# Altium schematic sheet ESP32_T&H_Interface.SchDoc(ESP32_T&H_Interface)
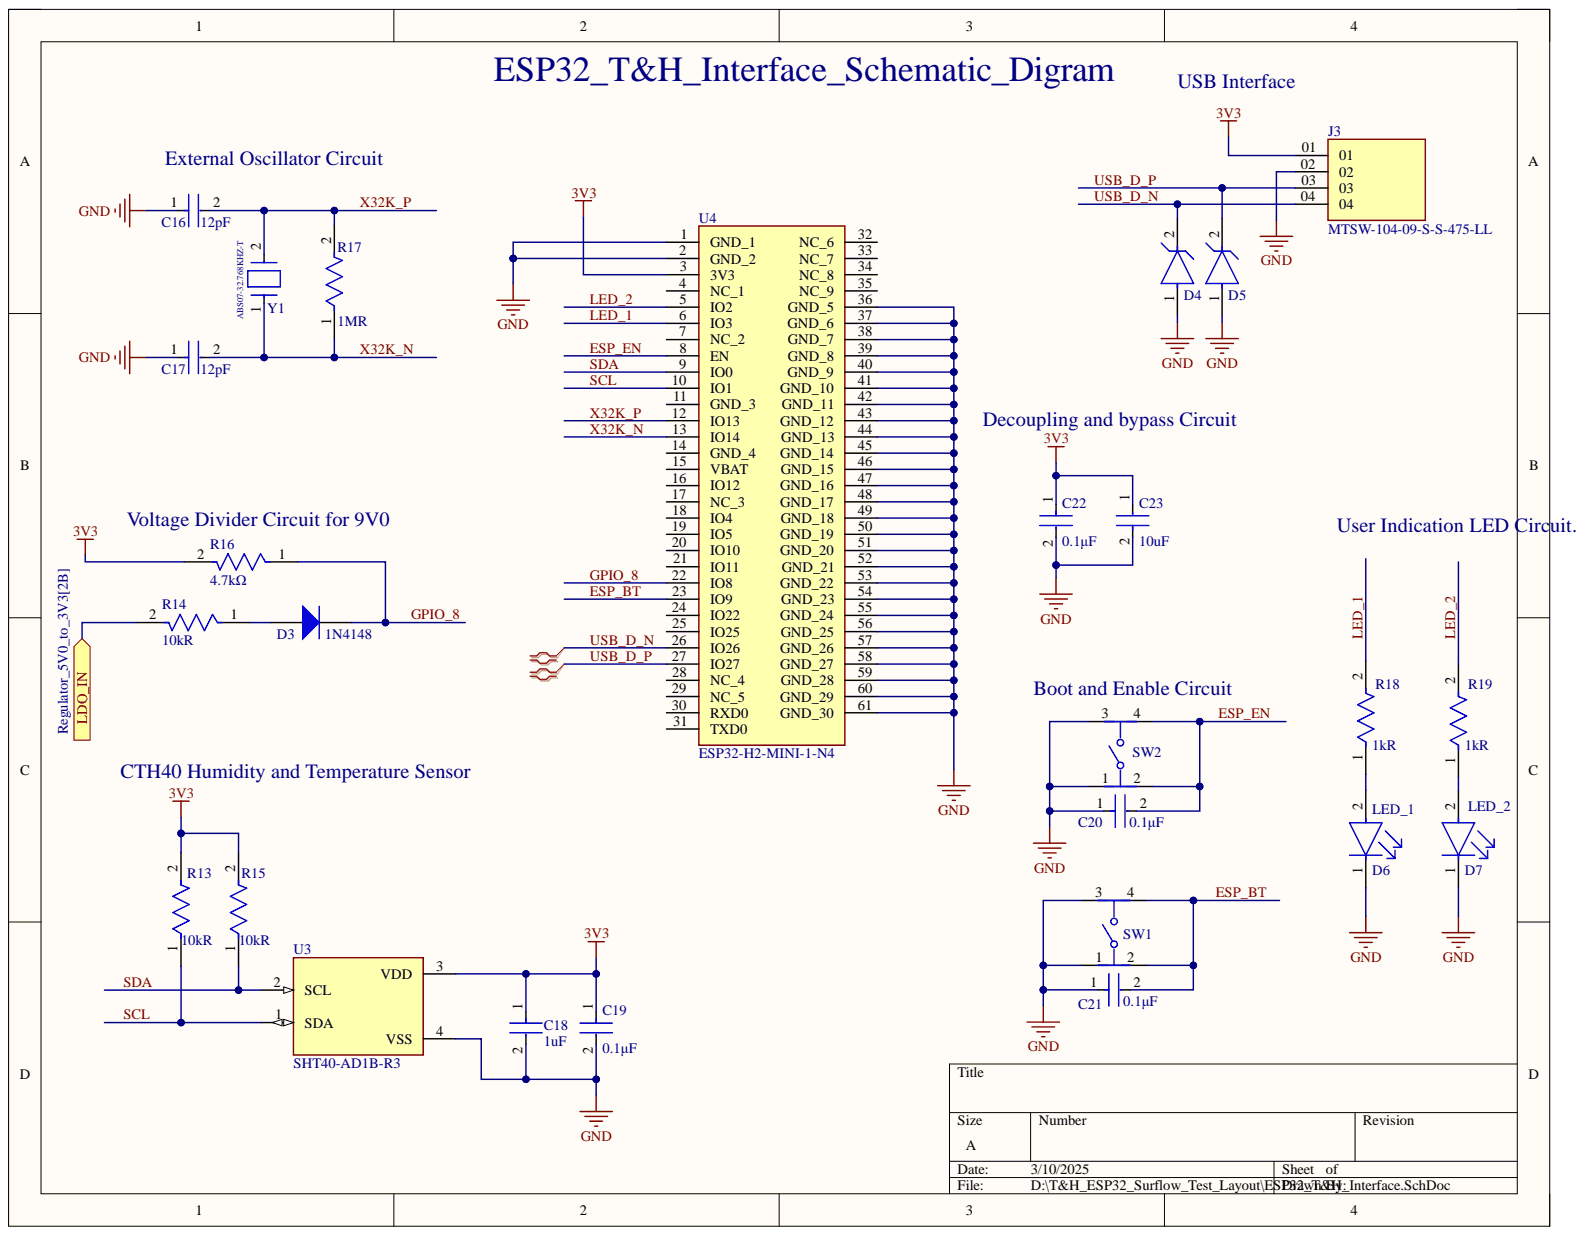
[diagram p0: ESP32_T&H_Interface.SchDoc]

## Parts
C16  Capacitance: 12pF  [FP-0402-L_1_0_1-W_0_5_0_1-IPC_B]  (hidden comment: 04025A120JAT2A)
C17  Capacitance: 12pF  [FP-0402-L_1_0_1-W_0_5_0_1-IPC_B]  (hidden comment: 04025A120JAT2A)
C18  value 1uF  Capacitance: 1uF  [FP-GRM15-0_1-MFG]  (hidden comment: GRM155R61A105KE15D)
C19  Capacitance: 0.1μF  [FP-C0603C-CF-MFG]  (hidden comment: C0603C104K8RACTU)
C20  Capacitance: 0.1μF  [FP-C0603C-CF-MFG]  (hidden comment: C0603C104K8RACTU)
C21  Capacitance: 0.1μF  [FP-C0603C-CF-MFG]  (hidden comment: C0603C104K8RACTU)
C22  Capacitance: 0.1μF  [FP-C0603C-CF-MFG]  (hidden comment: C0603C104K8RACTU)
C23  Capacitance: 10uF  [FP-C1608-080-0_1-IPC_A]  (hidden comment: C1608X5R1A106M080AC)
D3  1N4148  [DIOD-SOD323-2-A_V]
D4  UCLAMP3601P.TNT  [FP-SLP1006P2-MFG]  (value not drawn)
D5  UCLAMP3601P.TNT  [FP-SLP1006P2-MFG]  (value not drawn)
D6  APHHS1005CGCK  [FP-APHHS1005CGCK-MFG]  (value not drawn)
D7  APHHS1005CGCK  [FP-APHHS1005CGCK-MFG]  (value not drawn)
J3  MTSW-104-09-S-S-475-LL  [SAMTEC_MTSW-104-09-S-S-475-LL]
R13  Resistance: 10kR  [FP-AC0402-MFG]  (hidden comment: AC0402FR-0710KL)
R14  Resistance: 10kR  [FP-AC0402-MFG]  (hidden comment: AC0402FR-0710KL)
R15  Resistance: 10kR  [FP-AC0402-MFG]  (hidden comment: AC0402FR-0710KL)
R16  Resistance: 4.7kΩ  [FP-RC0402-0_4-MFG]  (hidden comment: RC0402JR-074K7L)
R17  Resistance: 1MR  [FP-MCR01-IPC_B]  (hidden comment: MCR01MRTF1004)
R18  Resistance: 1kR  [FP-RMCF0402-MFG]  (hidden comment: RMCF0402FT1K00)
R19  Resistance: 1kR  [FP-RMCF0402-MFG]  (hidden comment: RMCF0402FT1K00)
SW1  KMR221NGLFS  [FP-KMR221NGLFS-0]  (value not drawn)
SW2  KMR221NGLFS  [FP-KMR221NGLFS-0]  (value not drawn)
U3  SHT40-AD1B-R3  [SHT4X]
U4  ESP32-H2-MINI-1-N4  [ESP32H2MINI1N4]
Y1  ABS07-32.768KHZ-T  [FP-ABS07-MFG]

## Ports
LDO_IN

## Nets
3V3: C18.1 C19.1 C22.1 C23.1 J3.01 R13.2 R15.2 R16.2 U3.3(VDD) U4.3(3V3)
GND: C16.1 C17.1 C18.2 C19.2 C20.1 C21.1 C22.2 C23.2 D4.1 D5.1 D6.1 D7.1 J3.02 SW1.1 SW1.3 SW2.1 SW2.3 U3.4(VSS) U4.1(GND_1) U4.2(GND_2) U4.36(GND_5) U4.37(GND_6) U4.38(GND_7) U4.39(GND_8) U4.40(GND_9) U4.41(GND_10) U4.42(GND_11) U4.43(GND_12) U4.44(GND_13) U4.45(GND_14) U4.46(GND_15) U4.47(GND_16) U4.48(GND_17) U4.49(GND_18) U4.50(GND_19) U4.51(GND_20) U4.52(GND_21) U4.53(GND_22) U4.54(GND_23) U4.55(GND_24) U4.56(GND_25) U4.57(GND_26) U4.58(GND_27) U4.59(GND_28) U4.60(GND_29) U4.61(GND_30)
ESP_BT: C21.2 SW1.2 SW1.4 U4.23(IO9)
ESP_EN: C20.2 SW2.2 SW2.4 U4.8(EN)
GPIO_8: D3.2 R16.1 U4.22(IO8)
LDO_IN: R14.2 ; port LDO_IN
LED_1: R18.2 U4.6(IO3)
LED_2: R19.2 U4.5(IO2)
SCL: R13.1 U3.1(SDA) U4.10(IO1)
SDA: R15.1 U3.2(SCL) U4.9(IO0)
USB_D_N: D4.2 J3.04 U4.26(IO26)
USB_D_P: D5.2 J3.03 U4.27(IO27)
X32K_N: C17.2 R17.1 U4.13(IO14) Y1.1
X32K_P: C16.2 R17.2 U4.12(IO13) Y1.2
NetD3_1: D3.1 R14.1
NetD6_2: D6.2 R18.1
NetD7_2: D7.2 R19.1

Not connected: U4 4(NC_1) 7(NC_2) 11(GND_3) 14(GND_4) 15(VBAT) 16(IO12) 17(NC_3) 18(IO4) 19(IO5) 20(IO10) 21(IO11) 24(IO22) 25(IO25) 28(NC_4) 29(NC_5) 30(RXD0) 31(TXD0) 32(NC_6) 33(NC_7) 34(NC_8) 35(NC_9)

## Library data of the parts
C16 C17 = CMP-2008-02574-2: General Purpose Ceramic Capacitor, 0402, 12pF, 5%, C0G, 30ppm/°C, 50V; Insulation Resistance: 100GR; Case Code (Imperial): 0402; Case Code (Metric): 1005; Case/Package: 0402; Component Kind: Standard; Construction: Flat; Depth: 0.5mm; Dielectric: C0G; Height: 0.5mm; Lead Free: Lead Free; Length: 1mm; Library Name: Altium Content Vault; Max Operating Temperature: 125°C; Min Operating Temperature: -55°C; Mount: Surface Mount; Number of Pins: 2; Package Quantity: 1; Packaging: Tape and Reel; Radiation Hardening: No; REACH SVHC: No SVHC; RoHS Compliant: Yes; Series: -; Temperature Coefficient: 30ppm/°C; Termination: SMD/SMT; Thickness: 0.022inch; Tolerance: 5%; Voltage: 50V; Voltage Rating: 50V; Voltage Rating (DC): 50V; Width: 0.02inch
C18 = CMP-2008-04556-2: CAP CER 1UF 10V X5R 0402; Manufacturer: Murata; Material: Ceramic; Temperature Coefficient: 15%; Tolerance: 10%; Automotive: No; Category: Cap; Component Kind: Standard; ComponentLink2Description: Datasheet; ComponentLink2URL: https://search.murata.co.jp/Ceramy/image/img/A01X/G101/ENG/GRM155R61A105KE15-01A.pdf; Device Class L1: Passive Components; Device Class L2: Capacitors; Device Class L3: Ceramic Capacitors; Digikey Description: CAP CER 1UF 10V X5R 0402; Height: 0.55mm; Ipc Land Pattern Name: CAPC10050X50; Lead Free: Yes; Library Name: Altium Content Vault; Manufacturer Part Number: GRM155R61A105KE15D; Mouser Description: 0402 1 uF 10 V ±10% Tolerance X5R Surface Mount Multilayer Ceramic Capacitor; Octopart: https://octopart.com/grm155r61a105ke15d-murata-197442; Package: GRM15-0.1; RoHS: TRUE; Temperature: -55°C to +85°C; Temperature Characteristic: X5R; Temperature Range High: +85°C; Temperature Range Low: -55°C; Voltage Rating: 10V
C19-C22 = CMP-1035-02580-3: CAP CER 0.1UF 10V X7R 0603; Manufacturer: KEMET; Automotive Grade: Grade 1; Material: Ceramic; Temperature Coefficient: 30ppm/°C; Tolerance: 0.1; Value: 0.10 μF; Automotive: Yes; Category: Cap; Component Kind: Standard; ComponentLink2Description: Datasheet; ComponentLink2URL: https://api.kemet.com/component-edge/download/datasheet/C0603C100J5GACAUTO.pdf; Device Class L1: Passive Components; Device Class L2: Capacitors; Device Class L3: Ceramic Capacitors; Digikey Description: CAP CER 0.1UF 10V X7R 0603; Height: 0.87mm; Lead Free: Yes; Library Name: Altium Content Vault; Manufacturer Part Number: C0603C104K8RACTU; Mouser Description: Multilayer Ceramic Capacitors MLCC - SMD/SMT 10volts 0.1uF X7R 10%; Octopart: https://octopart.com/c0603c104k8ractu-kemet-145075; Package: C0603C-CF; RoHS: TRUE; Temperature: -55°C to +125°C; Temperature Characteristic: X7R; Temperature Range High: +125°C; Temperature Range Low: -55°C; Voltage Rating: 10 V
C23 = CMP-2000-04980-2: Multilayer Ceramic Capacitors 10μF ±20% 10V X5R SMD 0603; Case Code (Imperial): 0603; Case Code (Metric): 1608; Case/Package: 0603; Component Kind: Standard; Construction: Flat; Depth: 0.8mm; Dielectric: X5R; Dielectric Material: Ceramic; Height: 0.8mm; Lead Free: Lead Free; Length: 1.6mm; Library Name: Altium Content Vault; Max Operating Temperature: 85°C; Min Operating Temperature: -55°C; Mount: Surface Mount; Number of Pins: 2; Package Quantity: 1; Packaging: Tape and Reel; Radiation Hardening: No; REACH SVHC: No SVHC; Resistor Type: Ceramic; RoHS Compliant: Yes; Series: C; Termination: SMD/SMT; Thickness: 0.035inch; Tolerance: 20%; Voltage Rating: 10V; Voltage Rating (DC): 10V; Weight: 7.1E-05oz; Width: 0.031inch
D3 = CMP-1667-00004-1: Fast Switching Diode, 75 V, 0.3 A, -65 to 150 degC, 2-Pin SOD323, RoHS, Tape and Reel; Component Kind: Standard; ComponentLink1Description: Manufacturer URL; ComponentLink1URL: http://www.diodes.com/; ComponentLink2Description: Datasheet; ComponentLink2URL: http://www.diodes.com/datasheets/ds30097.pdf; DatasheetVersion: Rev. 25-2, 03/2016; Library Name: Altium Content Vault; PackageDescription: 2-Pin Small Outline Diode, Body 1.7 x 1.3 mm; PackageReference: SOD323-2
D4 D5 = CMP-56445-000005-1: TVS DIODE 33VWM 60VC SLP1006P2; Clamping Voltage: 60V; Diode Type: ZENER; Direction: Unidirectional; Element Configuration: Single; Leakage Current: 1uA; Max Breakdown Voltage: 45V; Min Breakdown Voltage: 36V; Number of Unidirectional Channels: 1; Peak Pulse Current: 2A; Peak Pulse Power: 125W; Power Dissipation: 170W; Power Line Protection: No; Reverse Standoff Voltage: 33V; Case Code (Imperial): 0402; Case Code (Metric): 1006; Case/Package: SMD/SMT; Clamping Voltage-Max: 60V; Component Kind: Standard; Depth: 0.6mm; Lead Free: Lead Free; Length: 1mm; Library Name: Altium Content Vault; Max Operating Temperature: 125°C; Min Operating Temperature: -40°C; Mount: Surface Mount; Number of Pins: 2; Packaging: Tape and Reel; Radiation Hardening: No; Resistor Type: Zener; RoHS Compliant: Yes; Series: Automotive, AEC-Q100, uClamp®; Working Voltage: 33V
D6 D7 = CMP-44529-000044-1: LED GREEN CLEAR CHIP SMD; Dominant Wavelength: 570nm; Forward Current: 20mA; Forward Voltage: 2.1V; Luminous Intensity: 50mcd; Number of LEDs: 1; Viewing Angle: 120°; Case Code (Imperial): 0402; Case Code (Metric): 1005; Case/Package: 0402; Color: Green; Component Kind: Standard; Height: 0.6mm; Illumination Color: Green; Lead Free: Lead Free; Length: 1mm; Lens Color: Clear; Lens Style: Clear; Library Name: Altium Content Vault; Max Operating Temperature: 85°C; Min Operating Temperature: -40°C; Mount: Surface Mount; Number of Pins: 2; Package Quantity: 2000; Packaging: Tape and Reel; Test Current: 20mA; Wavelength: 570nm; Width: 0.5mm
R13-R15 = CMP-2002-01790-3: RES 10kΩ ±1% 0.063W 0402; Max Power Dissipation: 63mW; Power Rating: 62.5mW; Temperature Coefficient: 100ppm/°C; Voltage Rating: 50V; Case Code (Imperial): 0402; Case Code (Metric): 1005; Case/Package: 0402; Component Kind: Standard; Construction: Rectangular; Depth: 0.5mm; Features: Moisture Resistant; Height: 0.015inch; Lead Free: Lead Free; Length: 0.039inch; Library Name: Altium Content Vault; Max Operating Temperature: 155°C; Military Standard: Not; Min Operating Temperature: -55°C; Number of Terminations: 2; Packaging: Tape and Reel; Resistor Type: Thick Film; RoHS Compliant: Yes; Series: AC; Size Code: 0402; Termination: SMD/SMT; Tolerance: 1%; Width: 0.02inch
R16 = CMP-2002-08011-2: Max Power Dissipation: 63mW; Temperature Coefficient: 100ppm/°C; Tolerance: 5%; Voltage Rating: 50V; Case Code (Imperial): 0402; Case/Package: 0402; Component Kind: Standard; Composition: Thick Film; Depth: 500µm; Features: Moisture Resistant; Height: 350µm; Lead Free: Lead Free; Length: 1mm; Library Name: Altium Content Vault; Max Operating Temperature: 155°C; Military Standard: Not; Min Operating Temperature: -55°C; Number of Pins: 2; Number of Terminations: 2; Packaging: Tape & Reel; Power Rating: 63mW; REACH SVHC: No SVHC; RoHS: Compliant; Schedule B: 8533210045, 8533210045; Termination: SMD/SMT; Voltage Rating (AC): 50V; Voltage Rating (DC): 50V; Width: 500µm
R17 = CMP-08839-019445-1: Thick Film Chip Resistor, 0402, 1MΩ, 1%, 100ppm/°C, 0.063W, 50V; Max Power Dissipation: 63mW; Case Code (Imperial): 0402; Case Code (Metric): 1005; Case/Package: 0402; Component Kind: Standard; Height: 0.016inch; Lead Free: Lead Free; Length: 0.039inch; Library Name: Altium Content Vault; Max Operating Temperature: 155°C; Min Operating Temperature: -55°C; Number of Terminations: 2; Package Quantity: 1; Packaging: Tape and Reel; Resistor Type: Thick Film; RoHS Compliant: Yes; Series: MCR; Temperature Coefficient: 100ppm/°C; Tolerance: 1%; Voltage Rating (DC): 50V; Width: 0.02inch
R18 R19 = CMP-26527-000026-1: 1kΩ ±1% 0.063W 0402 Thick Film Chip Resistor AEC-Q200 compliant; Max Power Dissipation: 63mW; Temperature Coefficient: 100ppm/°C; Case Code (Imperial): 0402; Case Code (Metric): 1005; Case/Package: 0402; Component Kind: Standard; Construction: Rectangular; Contact Plating: Tin; Depth: 0.5mm; Features: Automotive AEC-Q200; Height: 0.016inch; Lead Free: Lead Free; Length: 1mm; Library Name: Altium Content Vault; Max Operating Temperature: 155°C; Military Standard: Not; Min Operating Temperature: -55°C; Mount: Surface Mount; Number of Terminations: 2; Packaging: Tape and Reel; Power Rating: 63mW; Radiation Hardening: No; Resistor Type: Thick Film; RoHS Compliant: Yes; Series: RMCF; Size Code: 0402; Tolerance: 1%; Width: 0.02inch
SW1 SW2 = CMP-10040-000006-1: SWITCH TACTILE SPST-NO 0.05A 32V; Manufacturer: C&K; Contact Current Rating: 50mA; Contact Resistance: 100mΩ; Electromechanical Life: 200000Cycles; Operating Force: 2N; Automotive: No; Category: Switch; Component Kind: Standard; ComponentLink2Description: Datasheet; ComponentLink2URL: https://dznh3ojzb2azq.cloudfront.net/products/Tactile/KMR2/documents/datasheet.pdf; Device Class L1: Electromechanical; Device Class L2: Switches; Device Class L3: Tactile Switches; Digikey Description: SWITCH TACTILE SPST-NO 0.05A 32V; Height: 1.9mm; Lead Free: Yes; Library Name: Altium Content Vault; Manufacturer Part Number: KMR221NGLFS; Mount: Surface Mount; Mouser Description: Tactile Switches Tact; Octopart: https://octopart.com/kmr221nglfs-c%26k+components-7203802; Package: 0; RoHS: TRUE; Temperature: -40°C to +85°C; Temperature Range High: +85°C; Temperature Range Low: -40°C; Throw Configuration: SPST-NO; Voltage Rating Dc: 32V
U3 = SHT40-AD1B-R3: Digital Relative Humidity Temperature Sensor, Â±1.8 / max 3.5 %RH, Â±0.2 Â°C, Ultra-Low-Power, with filtermembrane; MF: Sensirion; Availability: In Stock; Check_prices: https://www.snapeda.com/parts/SHT40-AD1B-R3/Sensirion/view-part/?ref=eda; Component Kind: Standard; Library Name: SHT40-AD1B-R3.IntLib; MP: SHT40-AD1B-R3; Package: Package; Price: None; Purchase-URL: https://pricing.snapeda.com/search/part/SHT40-AD1B-R3/?ref=eda; SnapEDA_Link: https://www.snapeda.com/parts/SHT40-AD1B-R3/Sensirion/view-part/?ref=snap
U4 = ESP32-H2-MINI-1-N4: Integrated Circuit; Manufacturer_Name: Espressif Systems; Component Kind: Standard; Datasheet Link: https://www.espressif.com/sites/default/files/documentation/esp32-h2-mini-1_mini-1u_datasheet_en.pdf; Height: 2.55mm; Library Name: ESP32-H2-MINI-1-N4.IntLib; Manufacturer_Part_Number: ESP32-H2-MINI-1-N4; Mouser Part Number: 356-ESP32H2MINI1-N4; Mouser Price/Stock: https://www.mouser.co.uk/ProductDetail/Espressif-Systems/ESP32-H2-MINI-1-N4?qs=Jm2GQyTW%2FbiM%252BAVlvjt4eQ%3D%3D
Y1 = CMP-0447-00001-4: Crystal 32.768kHz ±20ppm (Tol) 12.5pF FUND 70kΩ 2-Pin CSMD T/R; ESR (Equivalent Series Resistance): 70kR; Case/Package: CSMD; Component Kind: Standard; Depth: 1.5mm; Frequency: 32.768kHz; Frequency Tolerance: 20ppm; Height: 0.9mm; Lead Free: Lead Free; Length: 3.2mm; Library Name: Altium Content Vault; Load Capacitance: 12.5pF; Max Operating Temperature: 85°C; Min Operating Temperature: -40°C; Number of Pins: 2; Operating Frequency: 32.768 kHz; Package Quantity: 3000; Packaging: Tape and Reel; Radiation Hardening: No; REACH SVHC: No SVHC; RoHS Compliant: Yes; Series: ABS07; Series Resistance: 70kR; Termination: SMD/SMT; Tolerance: 20ppm; Width: 1.5mm
J3 = MTSW-104-09-S-S-475-LL: Availability: Unavailable; Component Kind: Standard; Library Name: MTSW-104-09-S-S-475-LL.IntLib; MP: MTSW-104-09-S-S-475-LL; Package: None; Price: None
